# Altium schematic sheet DDS_Power_Supply.SchDoc(DDS_Power_Supply)
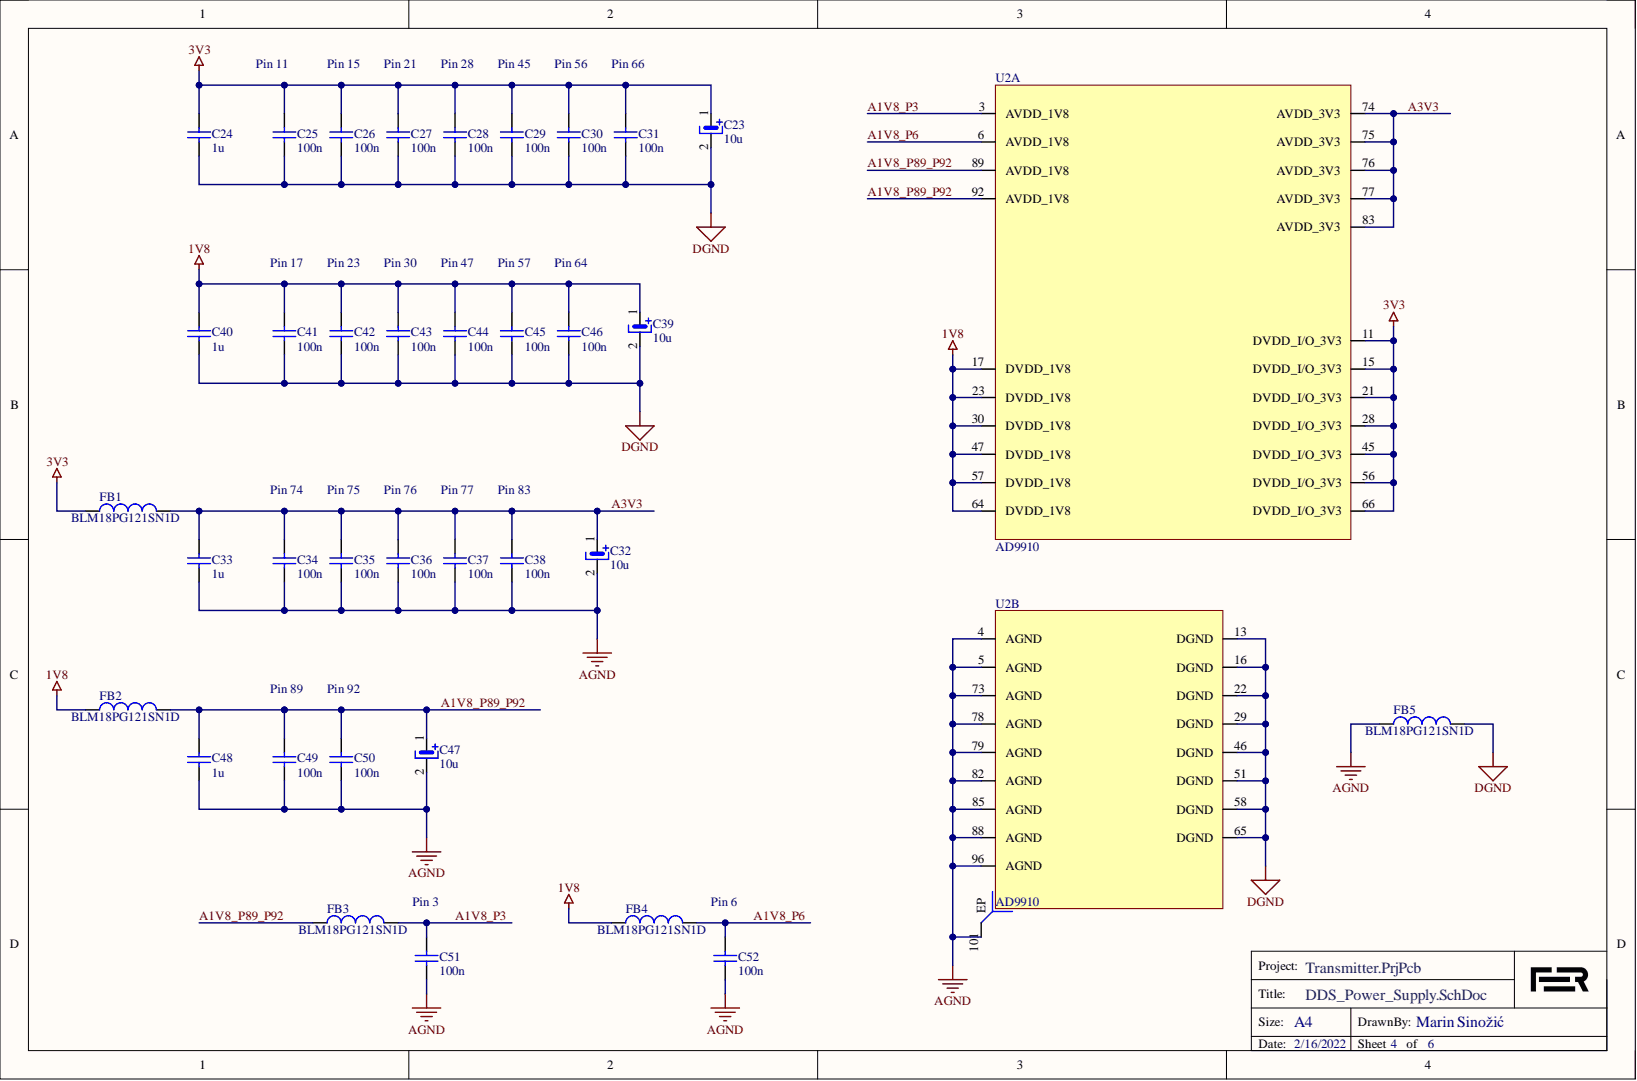
[diagram p0: DDS_Power_Supply.SchDoc]

## Parts
C23  10u  [0805]
C24  1u  [0805]
C25  100n  [0603]
C26  100n  [0603]
C27  100n  [0603]
C28  100n  [0603]
C29  100n  [0603]
C30  100n  [0603]
C31  100n  [0603]
C32  10u  [0805]
C33  1u  [0805]
C34  100n  [0603]
C35  100n  [0603]
C36  100n  [0603]
C37  100n  [0603]
C38  100n  [0603]
C39  10u  [0805]
C40  1u  [0805]
C41  100n  [0603]
C42  100n  [0603]
C43  100n  [0603]
C44  100n  [0603]
C45  100n  [0603]
C46  100n  [0603]
C47  10u  [0805]
C48  1u  [0805]
C49  100n  [0603]
C50  100n  [0603]
C51  100n  [0603]
C52  100n  [0603]
FB1  BLM18PG121SN1D  [0603]
FB2  BLM18PG121SN1D  [0603]
FB3  BLM18PG121SN1D  [0603]
FB4  BLM18PG121SN1D  [0603]
FB5  BLM18PG121SN1D  [0603]
U2  AD9910  [TSQFP50P1600X1600X105_HS-101N]  (parts A,B)

## Nets
1V8: C39.1 C40.2 C41.2 C42.2 C43.2 C44.2 C45.2 C46.2 FB2.1 FB4.1 U2.17(DVDD_1V8) U2.23(DVDD_1V8) U2.30(DVDD_1V8) U2.47(DVDD_1V8) U2.57(DVDD_1V8) U2.64(DVDD_1V8)
3V3: C23.1 C24.2 C25.2 C26.2 C27.2 C28.2 C29.2 C30.2 C31.2 FB1.1 U2.11(DVDD_I/O_3V3) U2.15(DVDD_I/O_3V3) U2.21(DVDD_I/O_3V3) U2.28(DVDD_I/O_3V3) U2.45(DVDD_I/O_3V3) U2.56(DVDD_I/O_3V3) U2.66(DVDD_I/O_3V3)
AGND: C32.2 C33.1 C34.1 C35.1 C36.1 C37.1 C38.1 C47.2 C48.1 C49.1 C50.1 C51.1 C52.1 FB5.1 U2.4(AGND) U2.5(AGND) U2.73(AGND) U2.78(AGND) U2.79(AGND) U2.82(AGND) U2.85(AGND) U2.88(AGND) U2.96(AGND) U2.101(EP)
DGND: C23.2 C24.1 C25.1 C26.1 C27.1 C28.1 C29.1 C30.1 C31.1 C39.2 C40.1 C41.1 C42.1 C43.1 C44.1 C45.1 C46.1 FB5.2 U2.13(DGND) U2.16(DGND) U2.22(DGND) U2.29(DGND) U2.46(DGND) U2.51(DGND) U2.58(DGND) U2.65(DGND)
A1V8_P3: C51.2 FB3.2 U2.3(AVDD_1V8)
A1V8_P6: C52.2 FB4.2 U2.6(AVDD_1V8)
A1V8_P89_P92: C47.1 C48.2 C49.2 C50.2 FB2.2 FB3.1 U2.89(AVDD_1V8) U2.92(AVDD_1V8)
A3V3: C32.1 C33.2 C34.2 C35.2 C36.2 C37.2 C38.2 FB1.2 U2.74(AVDD_3V3) U2.75(AVDD_3V3) U2.76(AVDD_3V3) U2.77(AVDD_3V3) U2.83(AVDD_3V3)

## Library data of the parts
C23 C32 C39 C47 = C_TANT_10uF: CAP TANT 10UF 20% 10V 0805; Manufacturer: Kyocera AVX; Manufacturer Part Number: 718-2490-1-ND; Dielectric Material: Tantalum; Dissipation Factor: 6%; ESR (Equivalent Series Resistance): 2R; Leakage Current: 1.6uA; Polarity: Polar; Q Factor: 6; Tolerance: 10%; Voltage: 16V; Capacitance: 10uF; Case Code (Imperial): 2412; Case Code (Metric): 6032; Case/Package: Molded; Category: Tantalum Capacitors; Component Kind: Standard; ComponentLink1Description: https://datasheet.ciiva.com/11793/0900766b80ccdc61-11793344.pdf?src-supplier=RSComponents; ComponentLink1URL: https://datasheet.ciiva.com/11793/0900766b80ccdc61-11793344.pdf?src-supplier=RSComponents; ComponentLink2Description: https://datasheet.ciiva.com/26341/559477-da-01-en-tacc-c-6-0-mm-x-3-2-mm-x-2-6-mm-10-uf-26341812.pdf?src-supplier=Conrad; ComponentLink2URL: https://datasheet.ciiva.com/26341/559477-da-01-en-tacc-c-6-0-mm-x-3-2-mm-x-2-6-mm-10-uf-26341812.pdf?src-supplier=Conrad; ComponentLink3Description: https://datasheet.ciiva.com/263/taj-263648.pdf?src-supplier=Digi-Key; ComponentLink3URL: https://datasheet.ciiva.com/263/taj-263648.pdf?src-supplier=Digi-Key; ComponentLink4Description: https://datasheet.ciiva.com/7730/taj-7730981.pdf?src-supplier=Element14; ComponentLink4URL: https://datasheet.ciiva.com/7730/taj-7730981.pdf?src-supplier=Element14; ComponentLink5Description: https://datasheet.ciiva.com/14605/70001050-14605654.pdf?src-supplier=Allied+Electronics; ComponentLink5URL: https://datasheet.ciiva.com/14605/70001050-14605654.pdf?src-supplier=Allied+Electronics; ComponentLink6Description: https://datasheet.ciiva.com/5936/13070-5936855.pdf?src-supplier=Farnell; ComponentLink6URL: https://datasheet.ciiva.com/5936/13070-5936855.pdf?src-supplier=Farnell; ComponentLink7Description: https://datasheet.ciiva.com/12911/taj-27497-12911160.pdf?src-supplier=Mouser; ComponentLink7URL: https://datasheet.ciiva.com/12911/taj-27497-12911160.pdf?src-supplier=Mouser; ComponentLink8Description: https://datasheet.ciiva.com/5937/taj-5937097.pdf?src-supplier=Farnell; ComponentLink8URL: https://datasheet.ciiva.com/5937/taj-5937097.pdf?src-supplier=Farnell; ComponentLink9Description: https://datasheet.ciiva.com/15163/getdatasheetpartid-120898-15163421.pdf?src-supplier=Verical; ComponentLink9URL: https://datasheet.ciiva.com/15163/getdatasheetpartid-120898-15163421.pdf?src-supplier=Verical; ComponentLink10Description: https://datasheet.ciiva.com/263/taj-263646.pdf?src-supplier=Digi-Key; ComponentLink10URL: https://datasheet.ciiva.com/263/taj-263646.pdf?src-supplier=Digi-Key; ComponentLink11Description: https://datasheet.ciiva.com/16492/taj-16492894.pdf?src-supplier=Future+Electronics; ComponentLink11URL: https://datasheet.ciiva.com/16492/taj-16492894.pdf?src-supplier=Future+Electronics; ComponentLink12Description: https://datasheet.ciiva.com/16632/taj-16632686.pdf?src-supplier=Avnet+Europe; ComponentLink12URL: https://datasheet.ciiva.com/16632/taj-16632686.pdf?src-supplier=Avnet+Europe; ComponentLink13Description: https://datasheet.ciiva.com/263/taj-263647.pdf?src-supplier=Digi-Key; ComponentLink13URL: https://datasheet.ciiva.com/263/taj-263647.pdf?src-supplier=Digi-Key; Depth: 3.2mm; Features: General Purpose; Height: 2.6mm; Height - Seated (Max): 0.11inch; Lead Free: Lead Free; Length: 6mm; Library Name: Tx_board.SchLib; Max Operating Temperature: 125°C; Min Operating Temperature: -55°C; Mount: Surface Mount,PCB; Number of Pins: 2; Package Quantity: 500; Packaging: Tape and Reel; Price: 0.36; Pricing 1: 1=0.63000, 10=0.44800, 100=0.29520 (USD); Radiation Hardening: No; REACH SVHC: No SVHC; RoHS: Yes; Stock 1: 740; Supplier 1: Digi-Key; Supplier Part Number 1: 478-1698-1-ND; Termination: SMD/SMT; Value: 10u; Voltage Rating: 16V; Voltage Rating (DC): 16V; Width: 3.2mm
C24 C33 C40 = Cap: CAP CER 1UF 25V X5R 0805; Manufacturer Part Number: 311-1868-1-ND; Component Kind: Standard; LatestRevisionDate: 17-Jul-2002; LatestRevisionNote: Re-released for DXP Platform.; Library Name: Miscellaneous Devices.IntLib; PackageDescription: Radial Cap, Thru-Hole; 2 Leads; 0.3 in Pin Spacing; PackageReference: RAD-0.3; Price: 0.21; Published: 8-Jun-2000; Signal Integrity: Cap; Simulation: CAP; Supplier 1: Digi-Key; Value: 1u; Publisher: Altium Limited
C25-C31 C34-C38 C41-C46 C49-C52 = Cap: CAP CER 0.1UF 50V X7R 0603; Manufacturer Part Number: 1276-CL10B104KB8WPNDCT-ND; Component Kind: Standard; LatestRevisionDate: 17-Jul-2002; LatestRevisionNote: Re-released for DXP Platform.; Library Name: Miscellaneous Devices.IntLib; PackageDescription: Radial Cap, Thru-Hole; 2 Leads; 0.3 in Pin Spacing; PackageReference: RAD-0.3; Price: 0.1; Published: 8-Jun-2000; Signal Integrity: Cap; Simulation: CAP; Supplier 1: Digi-Key; Value: 100n; Publisher: Altium Limited
C48 = Cap: CAP CER 1UF 25V X5R 0805; Manufacturer: 1276-1942-1-ND; Manufacturer Part Number: 311-1868-1-ND; Component Kind: Standard; LatestRevisionDate: 17-Jul-2002; LatestRevisionNote: Re-released for DXP Platform.; Library Name: Miscellaneous Devices.IntLib; PackageDescription: Radial Cap, Thru-Hole; 2 Leads; 0.3 in Pin Spacing; PackageReference: RAD-0.3; Price: 0.21; Published: 8-Jun-2000; Signal Integrity: Cap; Simulation: CAP; Supplier 1: Digi-Key; Value: 1u; Publisher: Altium Limited
FB1-FB5 = FB_0603_120R@100MHz: FERRITE BEAD 120 OHM 0603 1LN; Manufacturer: Murata; Manufacturer Part Number: 490-1037-1-ND; Current Rating: 2A; DC Resistance (DCR): 50mR; Frequency: 100MHz; Impedance: 120R; Material: Steel,Ferrite; Number of Circuits: 1; Shielding: Unshielded; Tolerance: 25%; Case Code (Imperial): 0603; Case Code (Metric): 1608; Case/Package: 0603; Category: Inductors; Component Kind: Standard; ComponentLink1Description: https://datasheet.ciiva.com/5709/1740433-5709171.pdf?src-supplier=Farnell; ComponentLink1URL: https://datasheet.ciiva.com/5709/1740433-5709171.pdf?src-supplier=Farnell; ComponentLink2Description: https://datasheet.ciiva.com/3854/5emiapbde-1-3854039.pdf?src-supplier=Arrow; ComponentLink2URL: https://datasheet.ciiva.com/3854/5emiapbde-1-3854039.pdf?src-supplier=Arrow; ComponentLink3Description: https://datasheet.ciiva.com/11799/0900766b81275ca5-11799546.pdf?src-supplier=RSComponents; ComponentLink3URL: https://datasheet.ciiva.com/11799/0900766b81275ca5-11799546.pdf?src-supplier=RSComponents; ComponentLink4Description: https://datasheet.ciiva.com/19454/1845013-19454715.pdf?src-supplier=Jameco; ComponentLink4URL: https://datasheet.ciiva.com/19454/1845013-19454715.pdf?src-supplier=Jameco; ComponentLink5Description: https://datasheet.ciiva.com/29347/442365-da-01-en-chip-drossel-0603-120r-2000ma-1-6x0-8mm-29347911.pdf?src-supplier=Conrad; ComponentLink5URL: https://datasheet.ciiva.com/29347/442365-da-01-en-chip-drossel-0603-120r-2000ma-1-6x0-8mm-29347911.pdf?src-supplier=Conrad; ComponentLink6Description: https://datasheet.ciiva.com/3081/l0110s0100blm18p-3081632.pdf?src-supplier=Avnet; ComponentLink6URL: https://datasheet.ciiva.com/3081/l0110s0100blm18p-3081632.pdf?src-supplier=Avnet; ComponentLink7Description: https://datasheet.ciiva.com/8021/1740433-8021493.pdf?src-supplier=Element14; ComponentLink7URL: https://datasheet.ciiva.com/8021/1740433-8021493.pdf?src-supplier=Element14; ComponentLink8Description: https://datasheet.ciiva.com/6212/l0110s0100blm18p-6212502.pdf?src-supplier=Digi-Key; ComponentLink8URL: https://datasheet.ciiva.com/6212/l0110s0100blm18p-6212502.pdf?src-supplier=Digi-Key; ComponentLink9Description: https://datasheet.ciiva.com/8021/1740433-8021494.pdf?src-supplier=Element14; ComponentLink9URL: https://datasheet.ciiva.com/8021/1740433-8021494.pdf?src-supplier=Element14; ComponentLink10Description: https://datasheet.ciiva.com/6212/l0110s0100blm18p-6212504.pdf?src-supplier=Digi-Key; ComponentLink10URL: https://datasheet.ciiva.com/6212/l0110s0100blm18p-6212504.pdf?src-supplier=Digi-Key; ComponentLink11Description: https://datasheet.ciiva.com/14122/blm-series-eng-tds-14122905.pdf?src-supplier=Distrelec; ComponentLink11URL: https://datasheet.ciiva.com/14122/blm-series-eng-tds-14122905.pdf?src-supplier=Distrelec; ComponentLink12Description: https://datasheet.ciiva.com/25903/l0110s0100blm18p-25903483.pdf?src-supplier=Avnet+Europe; ComponentLink12URL: https://datasheet.ciiva.com/25903/l0110s0100blm18p-25903483.pdf?src-supplier=Avnet+Europe; ComponentLink13Description: https://datasheet.ciiva.com/41449/001172297-da-01-en-drossel-0603-120r-1-6x0-8mm-4000st-41449659.pdf?src-supplier=Conrad; ComponentLink13URL: https://datasheet.ciiva.com/41449/001172297-da-01-en-drossel-0603-120r-1-6x0-8mm-4000st-41449659.pdf?src-supplier=Conrad; Core Material: Ferrite; DC Current: 2A; Depth: 0.8mm; Height: 0.8mm; Lead Free: Lead Free; Length: 1.6mm; Library Name: Tx_board.SchLib; Max Current Rating: 2A; Max DC Current: 2A; Max Operating Temperature: 125°C; Min Operating Temperature: -55°C; Number of Lines: 1; Number of Pins: 2; Package Quantity: 1; Packaging: Tape and Reel; Price: 0.1; Pricing 1: 1=0.10000, 10=0.07600, 25=0.06840, 50=0.05000, 100=0.04330, 250=0.03416, 500=0.02884, 1000=0.02429 (USD); Radiation Hardening: No; REACH SVHC: No SVHC; RoHS: Yes; Stock 1: 588284; Supplier 1: Digi-Key; Supplier Part Number 1: 490-1037-1-ND; Termination: SMD/SMT; Test Frequency: 100MHz; Width: 0.8mm
U2 = AD9910BSVZ: ANALOG DEVICES - AD9910BSVZ - Direct Digital Synthesizer, 1 GSPS. 14 Bit, 3.3 V,; Manufacturer Part Number: 505-AD9910BSVZ-ND; Component Kind: Standard; Library Name: Transmitter.SchLib; Price: 72.64; Supplier 1: Digi-Key
